# Altium schematic sheet Analog_Mulitiplexer.SchDoc
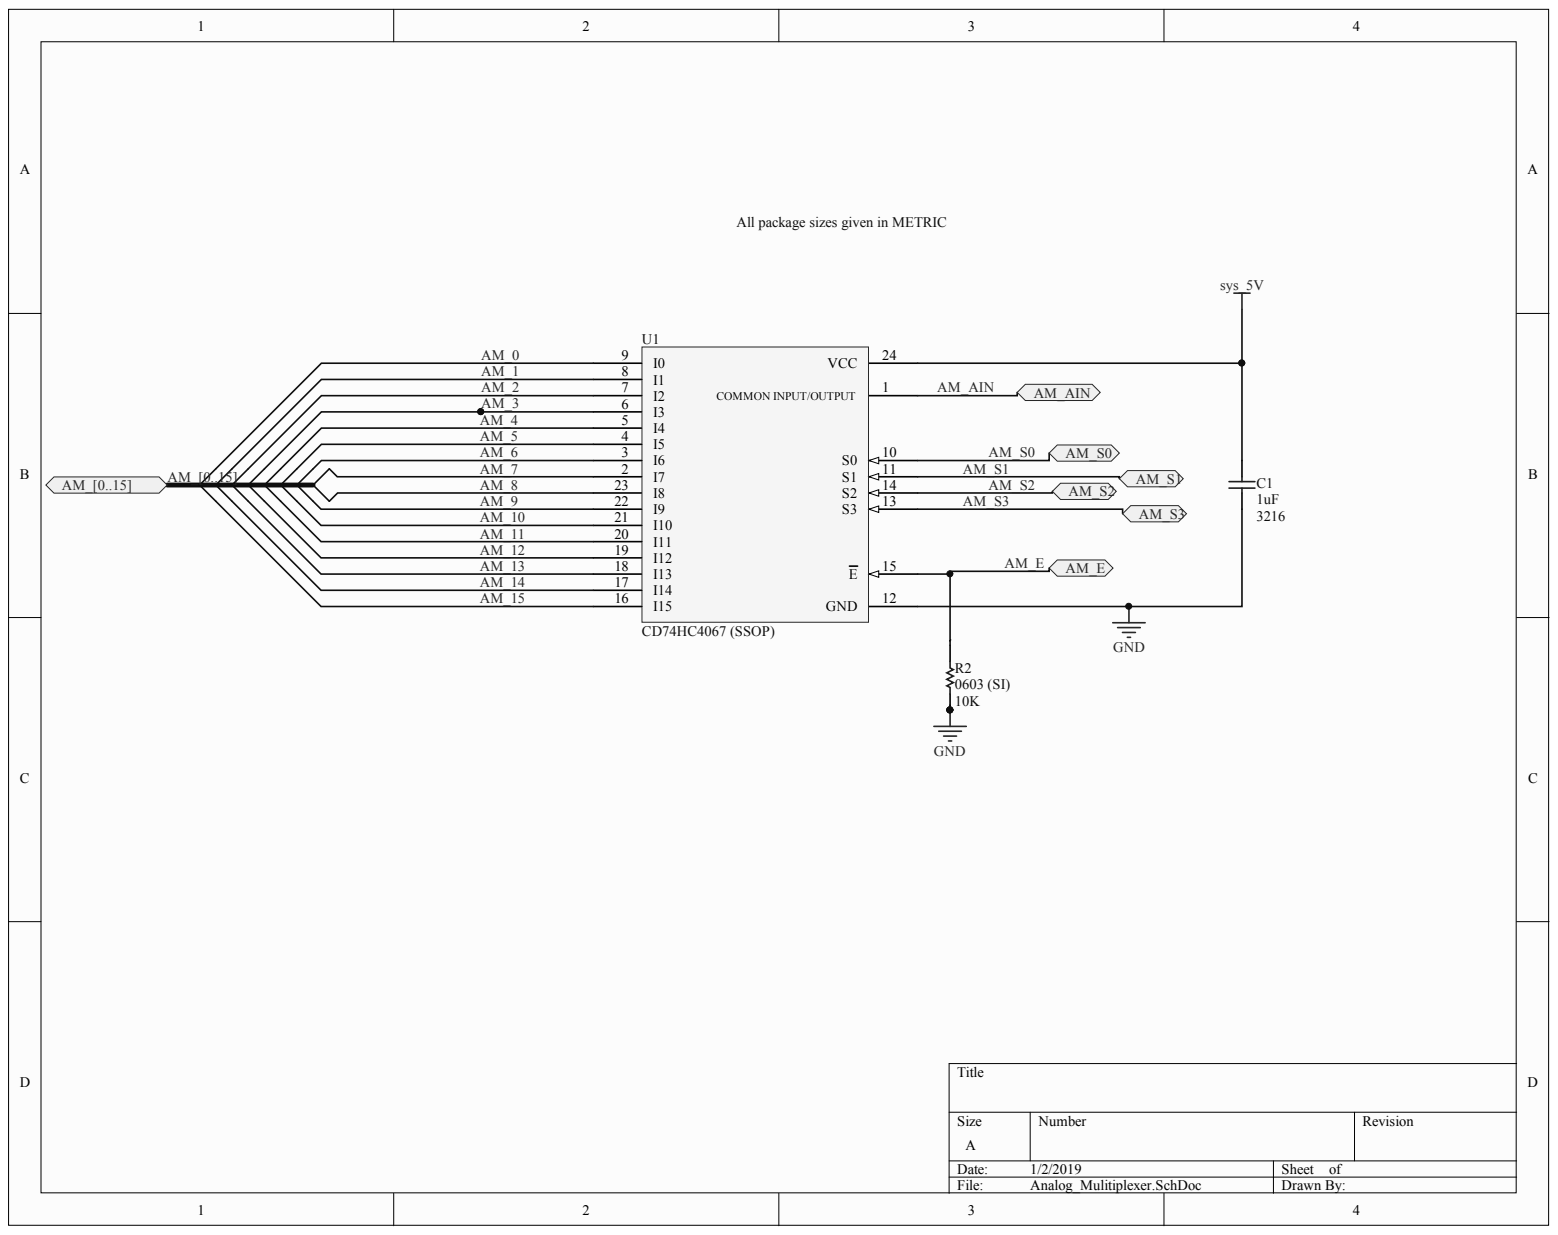
[diagram p0: Analog_Mulitiplexer.SchDoc]

## Parts
C1  Capacitance: 1uF  Case/Package (SI): 3216  [CAPC3216X180X50NL20T25]  (hidden comment: Kemet C1206C225K4PACTU)
R2  Resistance: 10K  [RESC0603X26X15ML03T05]  (hidden comment: Panasonic ERJ-1GN0R00C)
U1  CD74HC4067 (SSOP)  [SOIC127P1030X265-24N]

## Ports
AM_0, AM_1, AM_2, AM_3, AM_4, AM_5, AM_6, AM_7, AM_8, AM_9, AM_10, AM_11, AM_12, AM_13, AM_14, AM_15, AM_[0..15], AM_AIN, AM_E, AM_S0, AM_S1, AM_S2, AM_S3

## Nets
GND: C1.1 R2.1 U1.12(GND)
SYS_5V: C1.2 U1.24(VCC)
AM_0: U1.9(I0) ; port AM_[0..15]  [also labelled AM_]
AM_1: U1.8(I1) ; port AM_[0..15]  [also labelled AM_]
AM_2: U1.7(I2) ; port AM_[0..15]  [also labelled AM_]
AM_3: U1.6(I3) ; port AM_[0..15]  [also labelled AM_]
AM_4: U1.5(I4) ; port AM_[0..15]  [also labelled AM_]
AM_5: U1.4(I5) ; port AM_[0..15]  [also labelled AM_]
AM_6: U1.3(I6) ; port AM_[0..15]  [also labelled AM_]
AM_7: U1.2(I7) ; port AM_[0..15]  [also labelled AM_]
AM_8: U1.23(I8) ; port AM_[0..15]  [also labelled AM_]
AM_9: U1.22(I9) ; port AM_[0..15]  [also labelled AM_]
AM_10: U1.21(I10) ; port AM_[0..15]  [also labelled AM_]
AM_11: U1.20(I11) ; port AM_[0..15]  [also labelled AM_]
AM_12: U1.19(I12) ; port AM_[0..15]  [also labelled AM_]
AM_13: U1.18(I13) ; port AM_[0..15]  [also labelled AM_]
AM_14: U1.17(I14) ; port AM_[0..15]  [also labelled AM_]
AM_15: U1.16(I15) ; port AM_[0..15]  [also labelled AM_]
AM_AIN: U1.1 ; port AM_AIN
AM_E: R2.2 U1.15(E) ; port AM_E
AM_S0: U1.10(S0) ; port AM_S0
AM_S1: U1.11(S1) ; port AM_S1
AM_S2: U1.14(S2) ; port AM_S2
AM_S3: U1.13(S3) ; port AM_S3

## Library data of the parts
C1 = CMP-124457-2: CAP CER 2.2UF 16V 10% X5R 1206; Dielectric Material: Ceramic; Lead-Free Status: Lead Free; Voltage Rating (DC): 16.0 V; Capacitance Tolerance: ±10%; Case/Package: 1206; Component Kind: Standard; Dielectric Characteristic: X5R; Library Name: CommunityVault; Manufacturer Part Number: C1206C225K4PACTU; Mounting Style: Surface Mount; Number of Pins: 2; OctopartID: a5616c6acd317aa6; Octopart Url: https://octopart.com/c1206c225k4pactu-kemet-85302; Packaging: Cut Tape (CT); RoHS: Compliant; Size-Height: 0.0011 m; Size-Length: 0.0032 m; Size-Width: 0.0016 m
R2 = CMP-5632968-2: Thick Film Resistors - SMD 0201 Zero Ohms 1% Tol; Power Rating: 0.05 W; Voltage Rating (DC): 25.0 V; Case/Package: 0603 (SI); Component Kind: Standard; Library Name: CommunityVault; Lifecycle Status: Not Listed by Manufacturer; Manufacturer Part Number: ERJ-1GN0R00C; OctopartID: 781db1ede3b7a7da; Octopart Url: https://octopart.com/erj-1gn0r00c-panasonic-16432844; RoHS: Compliant
U1 = CMP-8e4b47469df5518c-1: High Speed CMOS 16-Channel Analog Multiplexer/Demultiplexer 24-SOIC -55 to 125; Component Kind: Standard; Library Name: CommunityVault; Mounting Style: Surface Mount
